# Altium schematic sheet ADPM.SchDoc(ADPM)
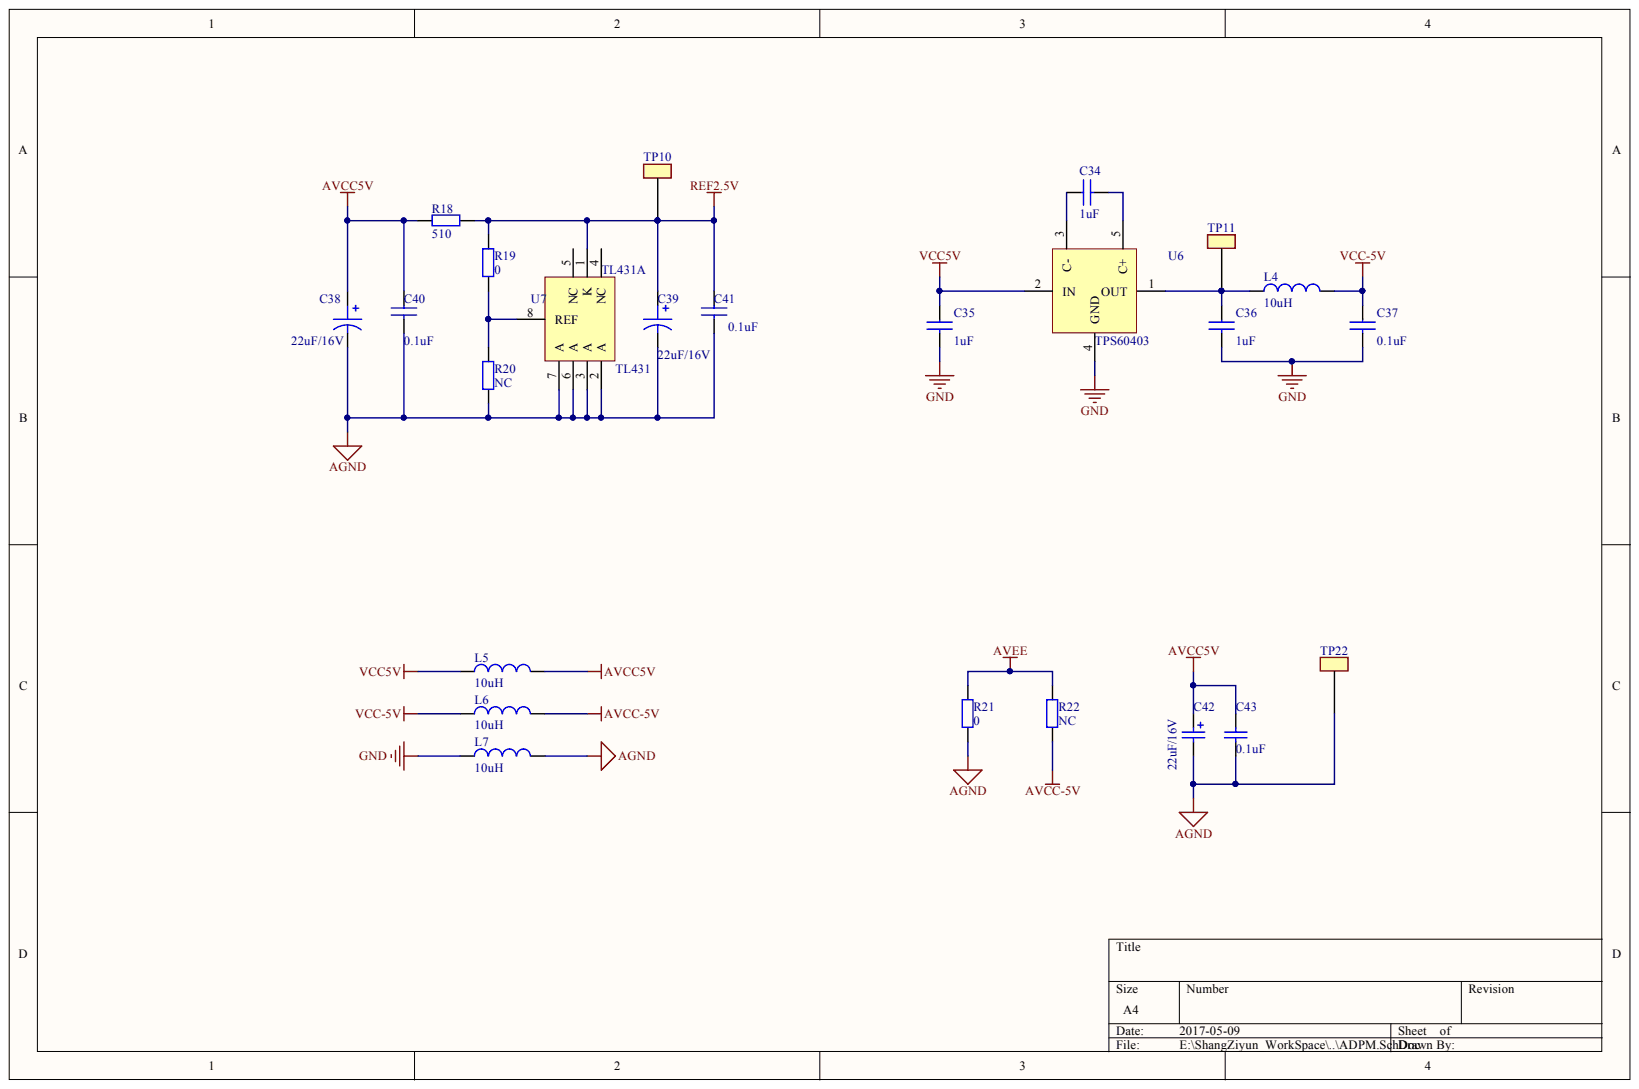
[diagram p0: ADPM.SchDoc]

## Parts
C34  value 1uF  [0603C]  (hidden comment: CAP NP)
C35  value 1uF  [0603C]  (hidden comment: CAP NP)
C36  value 1uF  [0603C]  (hidden comment: CAP NP)
C37  value 0.1uF  [0603C]  (hidden comment: CAP NP)
C38  value 22uF/16V  [EIA1206]  (hidden comment: CAPACITOR POL_3)
C39  value 22uF/16V  [EIA1206]  (hidden comment: CAPACITOR POL_3)
C40  value 0.1uF  [0603C]  (hidden comment: CAP NP)
C41  value 0.1uF  [0603C]  (hidden comment: CAP NP)
C42  22uF/16V  [EIA1206]
C43  value 0.1uF  [0603C]  (hidden comment: Capacitor)
L4  L?  value 10uH  [L0805]
L5  L?  value 10uH  [L0805]
L6  L?  value 10uH  [L0805]
L7  L?  value 10uH  [L0805]
R18  value 510  [L0603]  (hidden comment: Resistor)
R19  value 0  [L0603]  (hidden comment: Resistor)
R20  value NC  [L0603]  (hidden comment: Resistor)
R21  value 0  [L0603]  (hidden comment: Resistor)
R22  value NC  [L0603]  (hidden comment: Resistor)
TP10  TEST POINT_0  [TP]  (value not drawn)
TP11  TEST POINT_0  [TP]  (value not drawn)
TP22  TEST POINT_0  [TP]  (value not drawn)
U6  value TPS60403  [SOT23-5]  (hidden comment: TPS60400)
U7  TL431  value TL431A  [SOP8]

## Nets
AGND: C38.2 C39.2 C40.2 C41.2 C42.2 C43.2 L7.2 R20.1 R21.2 TP22.1 U7.2(A) U7.3(A) U7.6(A) U7.7(A)
AVCC5V: C38.1 C40.1 C42.1 C43.1 L5.2 R18.1
AVCC-5V: L6.2 R22.2
AVEE: R21.1 R22.1
GND: C35.2 C36.2 C37.2 L7.1 U6.4(GND)
REF2.5V: C39.1 C41.1 R18.2 R19.2 TP10.1 U7.1(K)
VCC5V: C35.1 L5.1 U6.2(IN)
VCC-5V: C37.1 L4.2 L6.1
NetC34_1: C34.1 U6.3(C-)
NetC34_2: C34.2 U6.5(C+)
NetC36_1: C36.1 L4.1 TP11.1 U6.1(OUT)
NetR19_1: R19.1 R20.2 U7.8(REF)

Not connected: U7 4(NC) 5(NC)

## Library data of the parts
C42 = Cap pol: Value: 22uF/6.3V钽电容; Component Kind: Standard; Library Name: LNG.SCHLIB
C43 = Cap: Component Kind: Standard; Description: Capacitor; LatestRevisionDate: 17-Jul-2002; LatestRevisionNote: Re-released for DXP Platform.; Library Name: Lib1.SCHLIB; PackageDescription: Radial Cap, Thru-Hole; 2 Leads; 0.3 in Pin Spacing; PackageReference: RAD-0.3; Published: 8-Jun-2000; Signal Integrity: Cap; Simulation: CAP; Publisher: Altium Limited
L4-L7 = Inductor: Component Kind: Standard; Library Name: MyLib.SCHLIB
R18-R22 = Res: Component Kind: Standard
  R21 R22: Library Name: Lib1.SCHLIB
  R18-R20: Library Name: LNG.SCHLIB
U7 = TL431-SOIC: Component Kind: Standard; Library Name: LNG.SCHLIB
C34-C37 C40 C41 = CAP NP: Component Kind: Standard; Library Name: MAINBOARD_Library.SchLib
C38 C39 = CAPACITOR POL_3: Component Kind: Standard; Library Name: SENSOR_BOARD_Library.SchLib
TP10 TP11 TP22 = TEST POINT_0: Component Kind: Standard; Library Name: MAINBOARD_Library.SchLib
U6 = TPS60400: Component Kind: Standard; Library Name: MyLib.SCHLIB
